# Altium schematic sheet Main.SchDoc
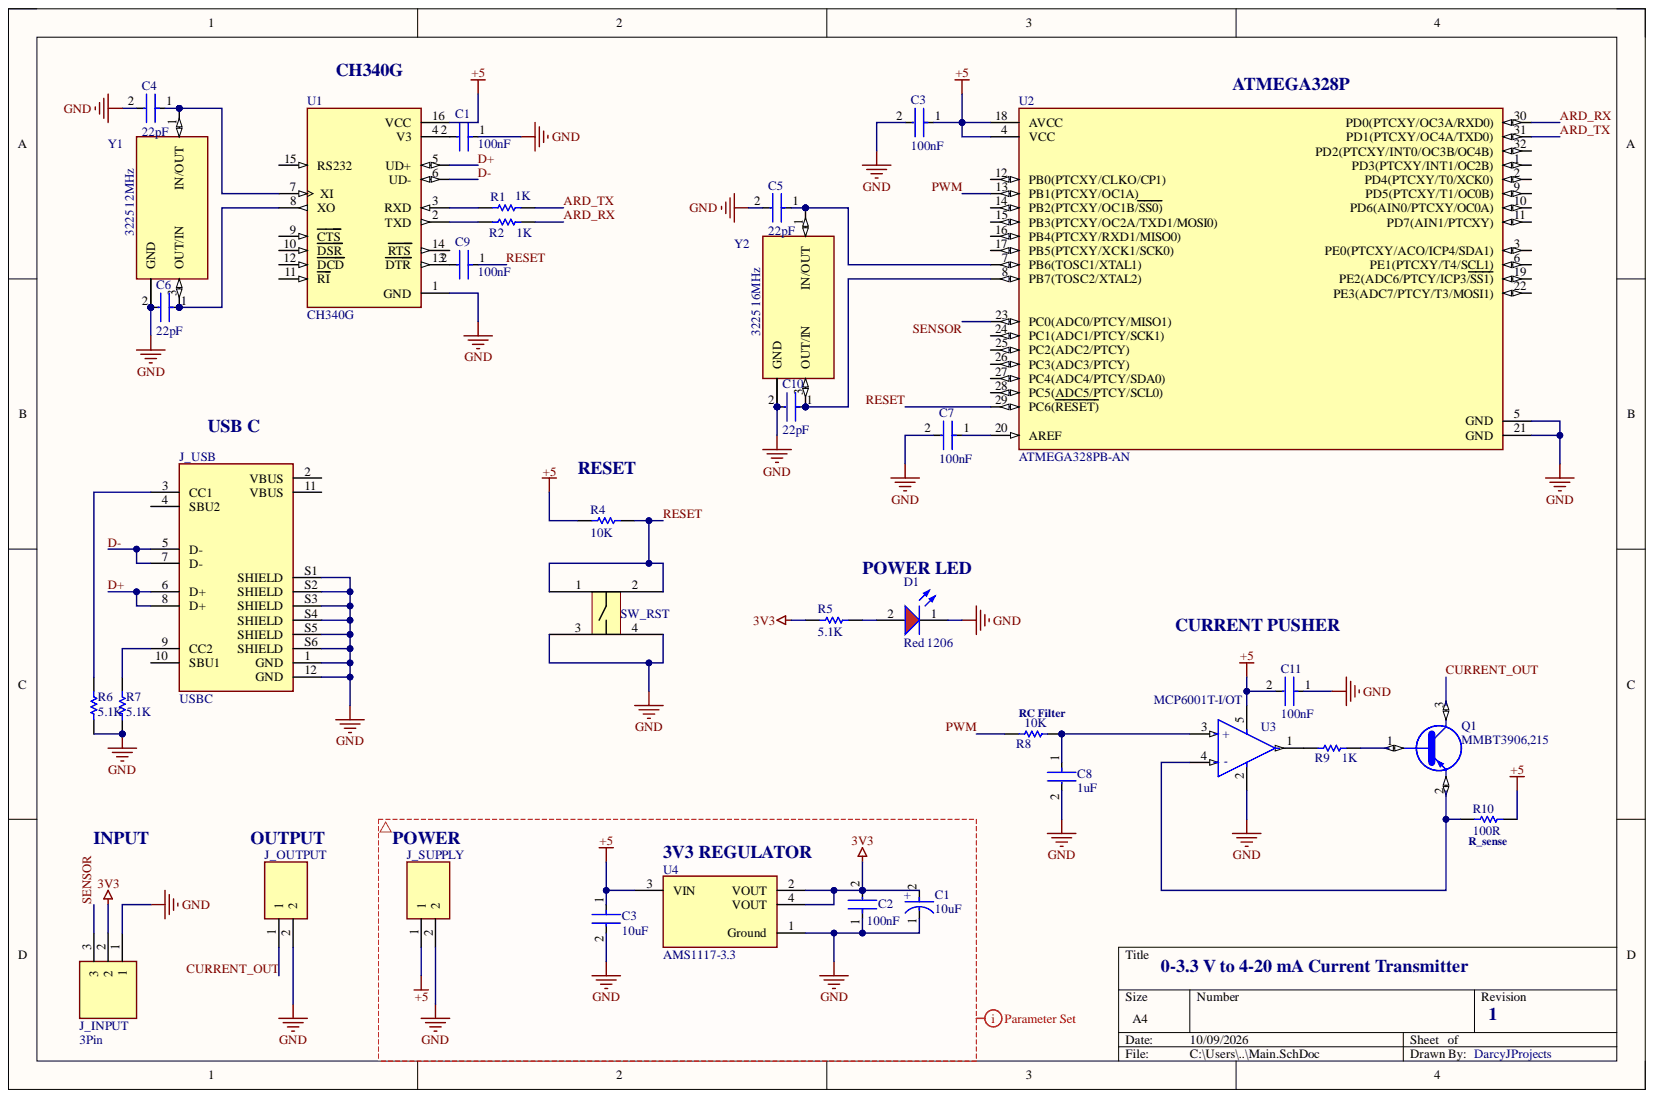
[diagram p0: Main.SchDoc]

## Parts
C1  10uF  [FP-FKB-MFG]
C1  100nF  [FP-CGA3E-0_3-0_1-IPC_A]
C2  100nF  [FP-1206-L_3_2_0_2-W_1_6_0-MFG]
C3  10uF  [FP-C3216-160-0_2-IPC_A]
C3  100nF  [FP-CGA3E-0_3-0_1-IPC_A]
C4  22pF  [FP-CGA3E-0_3-0_1-IPC_A]
C5  22pF  [FP-CGA3E-0_3-0_1-IPC_A]
C6  22pF  [FP-CGA3E-0_3-0_1-IPC_A]
C7  100nF  [FP-CGA3E-0_3-0_1-IPC_A]
C8  1uF  [FP-CGA3E-0_3-0_1-IPC_A]
C9  100nF  [FP-CGA3E-0_3-0_1-IPC_A]
C10  22pF  [FP-CGA3E-0_3-0_1-IPC_A]
C11  100nF  [FP-CGA3E-0_3-0_1-IPC_A]
D1  Red 1206  Case Code (Imperial): 1206  Color: Red  [WL-SMCW_1206_150120xx75000]
J_INPUT  3Pin  [15EDGRC-3.81-03P]
J_OUTPUT  15EDGRC-3.81-02P  [15EDGRC-3.81-02P]  (value not drawn)
J_SUPPLY  15EDGRC-3.81-02P  [15EDGRC-3.81-02P]  (value not drawn)
J_USB  USBC  [JAE_DX07S016JA1R1500]
Q1  MMBT3906,215  [SOT95P230X110-3N]
R1  1K  [RESC1608X55X30LL15T15]
R2  1K  [RESC1608X55X30LL15T15]
R4  10K  [RESC1608X55X30LL15T15]
R5  5.1K  [RESC1608X55X30LL15T15]
R6  5.1K  [RESC1608X55X30LL15T15]
R7  5.1K  [RESC1608X55X30LL15T15]
R8  10K  [RESC1608X55X30LL15T15]
R9  1K  [RESC1608X55X30LL15T15]
R10  100R  [RESC3216X70X50NL05T20]
SW_RST  3x4x2.5_TACTILE_BUTTON  [3x4x2.5_TACTILE_BUTTON]  (value not drawn)
U1  CH340G  [SOIC127P600X180-16N]
U2  ATMEGA328PB-AN  [FP-C04-074-MFG]
U3  MCP6001T-I/OT  [FP-C04-091-OT-IPC_A]
U4  AMS1117-3.3  [FP-AMS1117-IPC_C]
Y1  3225 12MHz  [XTAL_ECS-250-18-33B-JGN-TR]
Y2  3225 16MHz  [XTAL_ECS-250-18-33B-JGN-TR]

## Nets
3V3: C1.2 C2.2 J_INPUT.2 R5.1 U4.2(VOUT) U4.4(VOUT)
+5: C3.1 C11.2 J_SUPPLY.1 R4.2 R10.1 U1.16(VCC) U2.4(VCC) U2.18(AVCC) U3.5 U4.3(VIN)
GND: C1.1 C2.1 C3.2 C4.2 C5.2 C6.2 C7.2 C8.2 C10.2 C11.1 D1.1 J_INPUT.1 J_OUTPUT.2 J_SUPPLY.2 J_USB.1(GND) J_USB.12(GND) J_USB.S1(SHIELD) J_USB.S2(SHIELD) J_USB.S3(SHIELD) J_USB.S4(SHIELD) J_USB.S5(SHIELD) J_USB.S6(SHIELD) R6.1 R7.1 SW_RST.3 SW_RST.4 U1.1(GND) U2.5(GND) U2.21(GND) U3.2 U4.1(Ground) Y1.2(GND) Y1.4 Y2.2(GND) Y2.4
ARD_RX: R2.1 U2.30(PD0(PTCXY/OC3A/RXD0))
ARD_TX: R1.1 U2.31(PD1(PTCXY/OC4A/TXD0))
CURRENT_OUT: J_OUTPUT.1 Q1.3
D+: J_USB.6(D+) J_USB.8(D+) U1.5(UD+)
D-: J_USB.5(D-) J_USB.7(D-) U1.6(UD-)
PWM: R8.2 U2.13(PB1(PTCXY/OC1A))
RESET: C9.1 R4.1 SW_RST.1 SW_RST.2 U2.29(PC6(RESET))
SENSOR: J_INPUT.3 U2.23(PC0(ADC0/PTCY/MISO1))
NetC1_2: C1.2 U1.4(V3)
NetC4_1: C4.1 U1.7(XI) Y1.1(IN/OUT)
NetC5_1: C5.1 U2.7(PB6(TOSC1/XTAL1)) Y2.1(IN/OUT)
NetC6_1: C6.1 U1.8(XO) Y1.3(OUT/IN)
NetC7_1: C7.1 U2.20(AREF)
NetC8_1: C8.1 R8.1 U3.3(+)
NetC9_2: C9.2 U1.13(DTR)
NetC10_1: C10.1 U2.8(PB7(TOSC2/XTAL2)) Y2.3(OUT/IN)
NetD1_2: D1.2 R5.2
NetJ_USB_3: J_USB.3(CC1) R6.2
NetJ_USB_9: J_USB.9(CC2) R7.2
NetQ1_1: Q1.1 R9.1
NetQ1_2: Q1.2 R10.2 U3.4(-)
NetR1_2: R1.2 U1.3(RXD)
NetR2_2: R2.2 U1.2(TXD)
NetR9_2: R9.2 U3.1

Not connected: J_USB 2(VBUS) 4(SBU2) 10(SBU1) 11(VBUS); U1 9(CTS) 10(DSR) 11(RI) 12(DCD) 14(RTS) 15(RS232); U2 1(PD3(PTCXY/INT1/OC2B)) 2(PD4(PTCXY/T0/XCK0)) 3(PE0(PTCXY/ACO/ICP4/SDA1)) 6(PE1(PTCXY/T4/SCL1)) 9(PD5(PTCXY/T1/OC0B)) 10(PD6(AIN0/PTCXY/OC0A)) 11(PD7(AIN1/PTCXY)) 12(PB0(PTCXY/CLKO/CP1)) 14(PB2(PTCXY/OC1B/SS0)) 15(PB3(PTCXY/OC2A/TXD1/MOSI0)) 16(PB4(PTCXY/RXD1/MISO0)) 17(PB5(PTCXY/XCK1/SCK0)) 19(PE2(ADC6/PTCY/ICP3/SS1)) 22(PE3(ADC7/PTCY/T3/MOSI1)) 24(PC1(ADC1/PTCY/SCK1)) 25(PC2(ADC2/PTCY)) 26(PC3(ADC3/PTCY)) 27(PC4(ADC4/PTCY/SDA0)) 28(PC5(ADC5/PTCY/SCL0)) 32(PD2(PTCXY/INT0/OC3B/OC4B))

## Library data of the parts
C1 = CMP-2000-06211-2: CAP ALUM 10UF 20% 35V SMD; ESR (Equivalent Series Resistance): 1.35R; Leakage Current: 3uA; Life (Hours): 2000Hour; Material: Aluminium; Polarity: Polar; Ripple Current: 90mA; Capacitance: 10uF; Case/Package: Radial; Component Kind: Standard; Construction: Cylindrical; Contact Plating: Tin; Diameter: 4mm; Height: 5.8mm; Height - Seated (Max): 0.24inch; Impedance: 1.35R; Lead Free: Lead Free; Length: 5.8mm; Library Name: Altium Content Vault; Max Operating Temperature: 105°C; Min Operating Temperature: -55°C; Mount: Surface Mount; Number of Pins: 2; Package Quantity: 1; Packaging: Tape and Reel; Radiation Hardening: No; REACH SVHC: No SVHC; Ripple Current (AC): 90mA; RoHS Compliant: Yes; Series: FK; Termination: SMD/SMT; Tolerance: 20%; Voltage: 35V; Voltage Rating: 35V; Voltage Rating (DC): 35V; Width: 5.5mm
C1 C3-C11 = CMP-2000-07546-2: Cap Ceramic 100nF 50V X7R 10% Pad SMD 0603 +125°C Automotive T/R; Capacitance: 100nF; Case Code (Imperial): 0603; Case Code (Metric): 1608; Case/Package: 0603; Component Kind: Standard; Composition: Ceramic; Depth: 0.8mm; Dielectric: X7R; Height: 0.8mm; Lead Free: Lead Free; Length: 1.6mm; Library Name: Altium Content Vault; Max Operating Temperature: 125°C; Min Operating Temperature: -55°C; Mount: Surface Mount; Package Quantity: 1; Packaging: Tape and Reel; Radiation Hardening: No; Ratings: AEC-Q200; REACH SVHC: No SVHC; Termination: SMD/SMT; Thickness: 0.035inch; Tolerance: 10%; Voltage: 50V; Voltage Rating: 50V; Voltage Rating (DC): 50V; Weight: 7.1E-05oz; Width: 0.8mm
C2 = CMP-2000-05018-2: General Purpose Ceramic Capacitor, 1206, 100nF, 10%, X7R, 15%, 50V; Dissipation Factor: 2.5%; Insulation Resistance: 100GR; Capacitance: 100nF; Case Code (Imperial): 1206; Case Code (Metric): 3216; Case/Package: 1206; Component Kind: Standard; Construction: Flat; Contact Plating: Tin; Depth: 1.6mm; Dielectric: X7R; Dielectric Material: Ceramic; Height: 0.94mm; Lead Free: Lead Free; Length: 3.2mm; Library Name: Altium Content Vault; Max Operating Temperature: 125°C; Min Operating Temperature: -55°C; Mount: Surface Mount; Number of Pins: 2; Package Quantity: 1; Packaging: Tape and Reel; Radiation Hardening: No; REACH SVHC: No SVHC; RoHS Compliant: Yes; Series: -; Termination: SMD/SMT; Thickness: 0.037inch; Tolerance: 10%; Voltage: 50V; Voltage Rating: 50V; Voltage Rating (DC): 50V; Width: 1.6mm
C3 = CMP-2000-06045-2: Multilayer Ceramic Capacitors 10uF ±10% 50V X5R SMD 1206; Capacitance: 10uF; Case Code (Imperial): 1206; Case Code (Metric): 3216; Case/Package: 1206; Component Kind: Standard; Construction: Flat; Depth: 1.6mm; Dielectric: X5R; Dielectric Material: Ceramic; Height: 1.6mm; Lead Free: Lead Free; Length: 3.2mm; Library Name: Altium Content Vault; Max Operating Temperature: 85°C; Min Operating Temperature: -55°C; Mount: Surface Mount; Package Quantity: 1; Packaging: Tape and Reel; Radiation Hardening: No; REACH SVHC: No SVHC; Resistor Type: Ceramic; RoHS Compliant: Yes; Series: C; Termination: SMD/SMT; Thickness: 0.071inch; Tolerance: 10%; Voltage: 50V; Voltage Rating: 50V; Voltage Rating (DC): 50V; Weight: 0.000571oz; Width: 0.063inch
D1 = CMP-1426-00017-3: Led, Red, 630 Nm, 1.9 V, 30 Ma, 60 Mcd Rohs Compliant: Yes; Dominant Wavelength: 630nm; Forward Current: 100mA; Forward Voltage: 1.9V; Lens Style: Clear, Rectangular; Luminous Intensity: 60mcd; Max Reverse Voltage (DC): 5V; Peak Wavelength: 645nm; Test Current: 20mA; Viewing Angle: 140°; Case Code (Metric): 3216; Case/Package: 1206; Component Kind: Standard; Height: 680µm; Length: 3.2mm; Library Name: Altium Content Vault; Max Operating Temperature: 85°C; Min Operating Temperature: -40°C; Mount: Surface Mount; Packaging: Tape and Reel; REACH SVHC: Unknown; RoHS: Compliant; Wavelength: 630nm; Width: 1.6mm
J_INPUT = 15EDGRC-3.81-03P: Manufacturer_Name: Degson; Manufacturer_Part_Number: 15EDGRC-3.81-02P-14-00A(H); Geometry.Height: 7.2mm; Component Kind: Standard; Datasheet Link: https://www.tme.com/uz/ru/details/15edgrc3.81-02p/klemmnye-kolodki-razemnogo-tipa/degson-electronics/15edgrc-3-81-02p-14-00a-h/; Library Name: 15EDGRC-3.81-03P.SchLib
J_OUTPUT J_SUPPLY = 15EDGRC-3.81-02P: Manufacturer_Name: Degson; Manufacturer_Part_Number: 15EDGRC-3.81-02P-14-00A(H); Geometry.Height: 7.2mm; Component Kind: Standard; Datasheet Link: https://www.tme.com/uz/ru/details/15edgrc3.81-02p/klemmnye-kolodki-razemnogo-tipa/degson-electronics/15edgrc-3-81-02p-14-00a-h/; Library Name: 15EDGRC-3.81-02P.SchLib
J_USB = DX07S016JA1R1500: USB-C (USB TYPE-C) USB 2.0 Receptacle Connector 24 (16+8 Dummy) Position Surface Mount, Right Angle; Through Hole; Availability: Unavailable; Component Kind: Standard; Library Name: USBC_Fixed.SchLib; MP: DX07S016JA1R1500; Package: None; Price: None
Q1 = MMBT3906,215: Bipolar (BJT) Transistor PNP 40 V 200 mA 250MHz 250 mW Surface Mount TO-236AB; MF: Nexperia USA; Check_prices: https://www.snapeda.com/parts/MMBT3906,215/Nexperia/view-part/?ref=eda; Component Kind: Standard; Library Name: MMBT3906_215.IntLib; MP: MMBT3906,215; Package: SOT23-3 Nexperia USA Inc.; SnapEDA_Link: https://www.snapeda.com/parts/MMBT3906,215/Nexperia/view-part/?ref=snap
R1 R2 R4-R9 = CMP-2000-00803-1: Manufacturer: Panasonic; Part Number: ERJ-3GEYJ512V; Component Kind: Standard; Library Name: Altium Content Vault
R10 = CMP-2003-00592-1: Manufacturer: Panasonic; Part Number: ERJ-8GEYJ241V; Component Kind: Standard; Library Name: Altium Content Vault
U1 = CH340G: USB Interface Integrated Circuit; MF: WCH; Availability: Not in stock; Check_prices: https://www.snapeda.com/parts/Ch340G/WCH/view-part/?ref=eda; Component Kind: Standard; Library Name: CH340G.IntLib; MP: Ch340G; Package: None; Price: None; SnapEDA_Link: https://www.snapeda.com/parts/Ch340G/WCH/view-part/?ref=snap
U2 = CMP-06276-000029-1: IC MCU 8BIT 32KB FLASH 32TQFP; Manufacturer: Microchip; Core Architecture: AVR; Data Bus Width: 8bits; Frequency: 20MHz; Interface: I2C,SPI,USART,2-Wire; Max Supply Voltage: 5.5V; Memory Size: 32KBytes; Min Supply Voltage: 1.8V; Nominal Supply Current: 0.06-5.2mA; Number Of A/D Converters: 1; Number Of Adc Channels: 8; Number Of I2C Channels: 2; Number Of I/Os: 27; Number Of Pwm Channels: 10; Number Of Timers/Counters: 5; Ram Size: 2KBytes; Standoff Height: 0.05mm; Automotive: No; Category: IC; Component Kind: Standard; ComponentLink2Description: Datasheet; ComponentLink2URL: http://www.microchip.com/mymicrochip/filehandler.aspx?ddocname=en589665; Device Class L1: Integrated Circuits (ICs); Device Class L2: Embedded Processors and Controllers; Device Class L3: Microcontrollers; Digikey Description: IC MCU 8BIT 32KB FLASH 32TQFP; Footprint Url: https://ww1.microchip.com/downloads/en/DeviceDoc/32L_TQFP_7x7_PT_C04-0074B.pdf; Height: 1.2mm; Ipc Land Pattern Name: QFP80P900X900X120-32; Lead Free: Yes; Library Name: Altium Content Vault; Manufacturer Part Number: ATMEGA328PB-AN; Memory Type: FLASH; Mouser Description: AVR 32KBytes Flash, 1KBytes EEPROM, 2KBytes RAM, w - 20MHZ, TQFP, Extended TEMP, GREEN 5 V; Number Of Cores: 1; Number Of Spi Channels: 2; Number Of Uart Channels: 2; Octopart: https://octopart.com/atmega328pb-an-microchip-77760226; Package: C04-074; RoHS: TRUE; Temperature: -40°C to +105°C; Temperature Range High: +105°C; Temperature Range Low: -40°C
U3 = CMP-0187-00462-3: IC OPAMP GP 1 CIRCUIT SOT23-5; Bandwidth: 1MHz; Common Mode Rejection Ratio: 60dB; Input Bias Current: 1pA; Input Offset Voltage (Vos): 4.5mV; Max Supply Voltage: 6V; Min Supply Voltage: 1.8V; Nominal Supply Current: 100uA; Number of Amplifiers: 1; Operating Supply Voltage: 5.5V; Output Current: 23mA; Power Supply Rejection Ratio (PSRR): 86dB; Slew Rate: 0.6V/µs; Voltage Gain: 112dB; Case/Package: SOT-23; Component Kind: Standard; Contact Plating: Tin; Gain Bandwidth Product: 1MHz; Height: 1.3mm; Lead Free: Lead Free; Length: 3.1mm; Library Name: Altium Content Vault; Max Operating Temperature: 85°C; Min Operating Temperature: -40°C; Mount: Surface Mount; Number of Channels: 1; Number of Circuits: 1; Number of Elements: 1; Number of Pins: 5; Operating Supply Current: 100uA; Output Current per Channel: 23mA; Package Quantity: 1; Packaging: Tape and Reel; Radiation Hardening: No; REACH SVHC: No SVHC; Unity Gain Bandwidth Product: 1MHz; Voltage: 6V; Width: 1.8mm
U4 = CMP-209535-000001-1: LDO Voltage Regulators 1A, 3.3V; Manufacturer: Advanced Monolithic Systems; Dropout Voltage: 1.1V; Max Junction Temp: +125°C; Max Power Dissipation: 1.2W; Max Supply Voltage: 15V; Nominal Supply Current: 5mA; Number Of Outputs: 1; Output Type: Fixed; Standoff Height: 0.64mm; Automotive: No; Category: IC; Component Kind: Standard; ComponentLink2Description: Datasheet; ComponentLink2URL: http://www.advanced-monolithic.com/pdf/ds1117.pdf; Device Class L1: Integrated Circuits (ICs); Device Class L2: Power Management ICs; Device Class L3: Voltage Regulators - Linear; Height: 1.8mm; Ipc Land Pattern Name: SOT229P700X180-4; Lead Free: Yes; Library Name: Altium Content Vault; Manufacturer Part Number: AMS1117-3.3; Octopart: https://octopart.com/ams1117-3.3-advanced+monolithic+systems-25899300; Output Current: 1A; Output Voltage: 3.3V; Package: AMS1117; RoHS: TRUE; Temperature: -40°C to +125°C; Temperature Range High: +125°C; Temperature Range Low: -40°C
Y1 Y2 = ECS-250-18-33B-JGN-TR: Availability: Unavailable; Component Kind: Standard; Library Name: ECS-250-18-33B-JGN-TR.IntLib; MP: ECS-250-18-33B-JGN-TR; Package: None; Price: None
SW_RST = 3x4x2.5_TACTILE_BUTTON: Component Kind: Standard; Library Name: 3x4x2.5_TACTILE_BUTTON.SchLib
